# Altium schematic sheet USB & Battery Charging.SchDoc
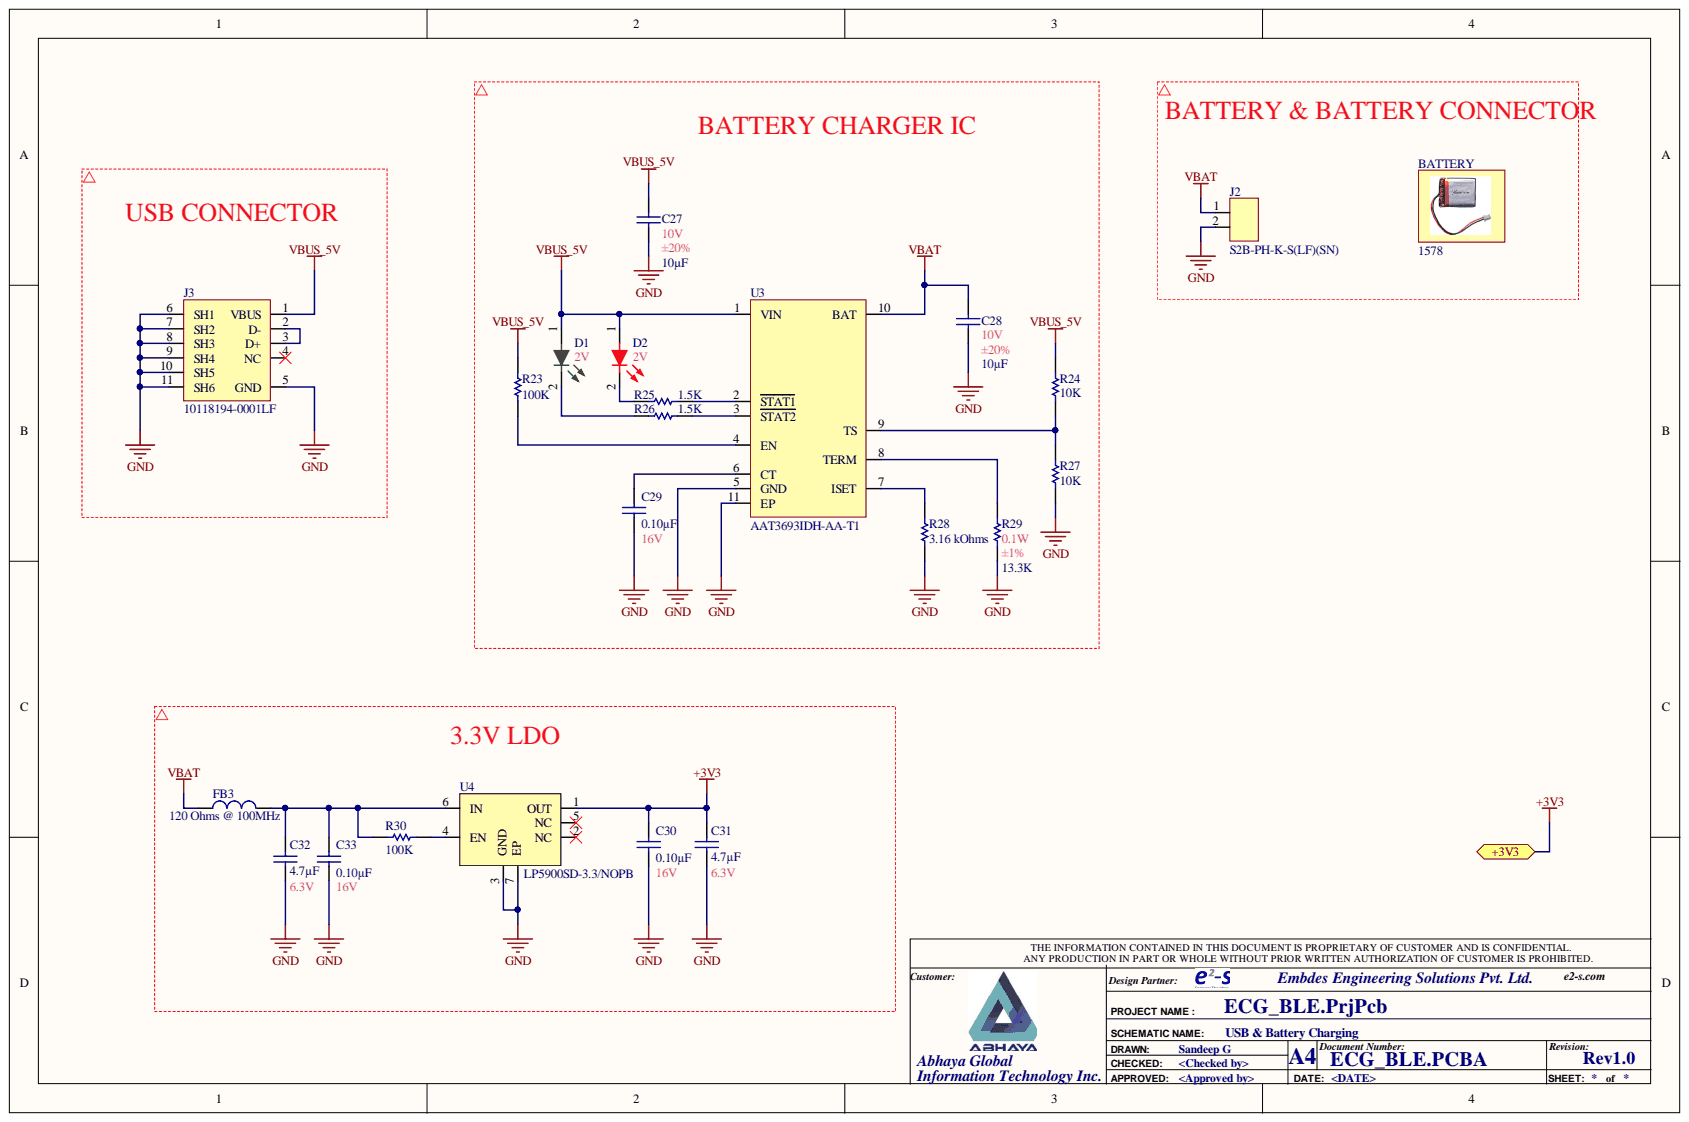
[diagram p0: USB & Battery Charging.SchDoc]

## Parts
BATTERY  value 1578  Manufacturer Part Number: 1578  (hidden comment: BATTERY LITHIUM 3.7V 500MAH)  (net tie)
C27  value 10µF  1st Auxiliary Field: 10V  2nd Auxiliary Field: ±20%  [SMDC0402]  (hidden comment: CAP CER 10UF 10V X5R 0402)
C28  value 10µF  1st Auxiliary Field: 10V  2nd Auxiliary Field: ±20%  [SMDC0402]  (hidden comment: CAP CER 10UF 10V X5R 0402)
C29  value 0.10µF  1st Auxiliary Field: 16V  [SMDC0402]  (hidden comment: CAP CER 0.1UF 16V X7R 0402)
C30  value 0.10µF  1st Auxiliary Field: 16V  [SMDC0402]  (hidden comment: CAP CER 0.1UF 16V X7R 0402)
C31  value 4.7µF  1st Auxiliary Field: 6.3V  [SMDC0402]  (hidden comment: CAP CER 4.7UF 6.3V X5R 0402)
C32  value 4.7µF  1st Auxiliary Field: 6.3V  [SMDC0402]  (hidden comment: CAP CER 4.7UF 6.3V X5R 0402)
C33  value 0.10µF  1st Auxiliary Field: 16V  [SMDC0402]  (hidden comment: CAP CER 0.1UF 16V X7R 0402)
D1  1st Auxiliary Field: 2V  [SMDLED0603]  (hidden comment: LED GREEN CLEAR SMD)
D2  1st Auxiliary Field: 2V  [SMDLEDR0603]  (hidden comment: LED RED CLEAR 0603 SMD)
FB3  value 120 Ohms @ 100MHz  [SMDL0402]  (hidden comment: FERRITE BEAD 120 OHM 0402 1LN)
J2  value S2B-PH-K-S(LF)(SN)  Manufacturer Part Number: S2B-PH-K-S(LF)(SN)  [SHDRRA2W70P0_200_1X2_590X790X550P]  (hidden comment: CONN HOUSING PH 2POS 2MM WHITE)
J3  value 10118194-0001LF  Manufacturer Part Number: 10118194-0001LF  [USB_MICRO_B_10118194-0001LF]  (hidden comment: CONN USB MICRO B RECPT SMT R/A)
R23  value 100K  [SMDRC0402]  (hidden comment: RES SMD 100K OHM 1% 1/10W 0402)
R24  value 10K  [SMDR0402]  (hidden comment: RES SMD 10K OHM 1% 1/10W 0402)
R25  value 1.5K  [SMDR0402]  (hidden comment: RES SMD 1.5K OHM 1% 1/10W 0402)
R26  value 1.5K  [SMDR0402]  (hidden comment: RES SMD 1.5K OHM 1% 1/10W 0402)
R27  value 10K  [SMDR0402]  (hidden comment: RES SMD 10K OHM 1% 1/10W 0402)
R28  value 3.16 kOhms  [SMDR0402]  (hidden comment: RES 3.16K OHM 1% 1/10W 0402)
R29  value 13.3K  1st Auxiliary Field: 0.1W  2nd Auxiliary Field: ±1%  [SMDR0402]  (hidden comment: RES SMD 13.3K OHM 1% 1/10W 0402)
R30  value 100K  [SMDRC0402]  (hidden comment: RES SMD 100K OHM 1% 1/10W 0402)
U3  value AAT3693IDH-AA-T1  Manufacturer Part Number: AAT3693IDH-AA-T1  [SON40P220X220X80-11N]  (hidden comment: 1.6A LINEAR LI-ION CHGR 10TDFN)
U4  value LP5900SD-3.3/NOPB  Manufacturer Part Number: LP5900SD-3.3/NOPB  [SON65P250X80_HS-7N]  (hidden comment: IC REG LINEAR 3.3V 150MA 6WSON)

## Ports
+3V3

## Nets
GND: C27.2 C28.2 C29.1 C30.1 C31.1 C32.1 C33.1 J2.2 J3.5(GND) J3.6(SH1) J3.7(SH2) J3.8(SH3) J3.9(SH4) J3.10(SH5) J3.11(SH6) R27.2 R28.2 R29.2 U3.5(GND) U3.11(EP) U4.3(GND) U4.7(EP)
VBAT: C28.1 FB3.1 J2.1 U3.10(BAT)
VBUS_5V: C27.1 D1.1 D2.1 J3.1(VBUS) R23.1 R24.1 U3.1(VIN)
+3V3: C30.2 C31.2 U4.1(OUT) ; port +3V3
NetC29_2: C29.2 U3.6(CT)
NetC32_2: C32.2 C33.2 FB3.2 R30.1 U4.6(IN)
NetD1_2: D1.2 R26.2
NetD2_2: D2.2 R25.2
NetJ3_2: J3.2(D-) J3.3(D+)
NetR23_2: R23.2 U3.4(EN)
NetR24_2: R24.2 R27.1 U3.9(TS)
NetR25_1: R25.1 U3.2(STAT1)
NetR26_1: R26.1 U3.3(STAT2)
NetR28_1: R28.1 U3.7(ISET)
NetR29_1: R29.1 U3.8(TERM)
NetR30_2: R30.2 U4.4(EN)

Not connected: J3 4(NC); U4 2(NC) 5(NC)

## Library data of the parts
BATTERY = EMS-1578: Manufacturer: Adafruit Industries LLC; Vendor Part Number: 1528-1841-ND; 3rd Auxiliary Field: RoHS Compliant; 4th Auxiliary Field: 503035; Component Height (mm): 4.8mm; Vendor Name: Digi-Key; 1st Auxiliary Field: 3.7V; 2nd Auxiliary Field: 500mAh; Description: BATTERY LITHIUM 3.7V 500MAH; Internal Part Number: EMS-1578; Part Type: BATTERY LITHIUM 3.7V 500MAH; Library Name: ECG_BLE.SchLib
C27 C28 = ECA10U0M10V0-SB: Manufacturer: Samsung Electro-Mechanics America, Inc.; Manufacturer Part Number: CL05A106MP5NUNC; Vendor Part Number: 1276-1450-1-ND; Component Height (mm): 0.70mm; Vendor Name: DigiKey; Component Kind: Standard; Description: CAP CER 10UF 10V X5R 0402; Internal Part Number: ECA10U0M10V0-SB; Library Name: Master_ Library.SchLib; Part Type: CAP CER 10UF 10V X5R 0402; Temp Co: X5R
C29 C30 C33 = ECA0U10K16V0-1-SB: Manufacturer: Samsung Electro-Mechanics America, Inc.; Manufacturer Part Number: CL05B104KO5NNNC; Vendor Part Number: 1276-1001-1-ND; 2nd Auxiliary Field: ±10%; Vendor Name: Digi-Key; Component Kind: Standard; Description: CAP CER 0.1UF 16V X7R 0402; Internal Part Number: ECA0U10K16V0-1-SB; Library Name: Master_ Library.SchLib; Part Type: CAP CER 0.1UF 16V X7R 0402; Temp Co: X7R
C31 C32 = ECA4U70N6V30-SB: Manufacturer: Murata Electronics North America; Manufacturer Part Number: GRM155R60J475ME47D; Vendor Part Number: 490-5915-1-ND; 2nd Auxiliary Field: ±20%; 3rd Auxiliary Field: RoHS Compliant; Component Height (mm): 0.60mm; Temp Co: -55°C ~ 85°C; Vendor Name: Digi-Key; Component Kind: Standard; Description: CAP CER 4.7UF 6.3V X5R 0402; Internal Part Number: ECA4U70N6V30-SB; Library Name: Integrated_Master_Library.IntLib; Part Type: CAP CER 4.7UF 6.3V X5R 0402
D1 = ELDG2V0020M0-SC: Manufacturer: Lite-On Inc.; Manufacturer Part Number: LTST-C191KGKT; Vendor Part Number: 160-1446-1-ND; 2nd Auxiliary Field: 20mA; 3rd Auxiliary Field: RoHS Compliant; Component Height (mm): 0.55mm; Value: LTST-C191KGKT; Vendor Name: Digi-Key; Component Kind: Standard; Description: LED GREEN CLEAR SMD; Internal Part Number: ELDG2V0020M0-SC; Library Name: Master_ Library.SchLib; Part Type: LED GREEN CLEAR SMD
D2 = ELDR2V0020M0-SC-2: Manufacturer: Würth Elektronik; Manufacturer Part Number: 150060RS75000; Vendor Part Number: 732-4978-1-ND; 2nd Auxiliary Field: 20mA; 3rd Auxiliary Field: RoHS Compliant; Component Height (mm): 0.8mm; Value: 150060RS75000; Vendor Name: Digi-Key; Component Kind: Standard; Description: LED RED CLEAR 0603 SMD; Internal Part Number: ELDR2V0020M0-SC-2; Library Name: Master_ Library.SchLib; Part Type: LED RED CLEAR 0603 SMD
FB3 = EMS-BLM15PX121SN1D: Manufacturer: Murata Electronics; Vendor Part Number: 490-9656-1-ND; 1st Auxiliary Field: 2A; 2nd Auxiliary Field: 55mOhm; 3rd Auxiliary Field: ROHS3 Compliant; Component Height (mm): 0.55mm; Temp Co: -55°C ~ 125°C; Vendor Name: Digi-Key; Component Kind: Standard; Description: FERRITE BEAD 120 OHM 0402 1LN; Internal Part Number: EMS-BLM15PX121SN1D; Manufacturer Part Number: BLM15PX121SN1D; Part Type: FERRITE BEAD 120 OHM 0402 1LN; Library Name: ECG_BLE.SchLib
J2 = ECNJSTHE02-T: Manufacturer: JST Sales America Inc.; Vendor Part Number: 455-1719-ND; Component Height (mm): 4.8mm; Vendor Name: Digi-Key; 1st Auxiliary Field: 2 Pos; 2nd Auxiliary Field: 2mm; Component Kind: Standard; Description: CONN HOUSING PH 2POS 2MM WHITE; Internal Part Number: ECNJSTHE02-T; Library Name: Master_ Library.SchLib; Part Type: CONN HOUSING PH 2POS 2MM WHITE
J3 = EINUSBBR06-S-1364: Manufacturer: Amphenol FCI; Vendor Part Number: 609-4618-1-ND; 1st Auxiliary Field: USB - micro B; 2nd Auxiliary Field: 5 POS; 3rd Auxiliary Field: RoHS Compliant; Component Height (mm): 3mm; Temp Co: -30°C ~ 80°C; Vendor Name: Digi-Key; Component Kind: Standard; Description: CONN USB MICRO B RECPT SMT R/A; Internal Part Number: EINUSBBR06-S-1364; Library Name: Master_ Library.SchLib; Part Type: CONN USB MICRO B RECPT SMT R/A
R23 R30 = ERE100KG110-SB: Manufacturer: Panasonic Electronic Components; Manufacturer Part Number: ERJ-2RKF1003X; Vendor Part Number: P100KLCT-ND; 1st Auxiliary Field: 0.1W; Component Height (mm): 0.40mm; Temp Co: ±100ppm/°C; Vendor Name: Digi-Key; 2nd Auxiliary Field: ±1%; Component Kind: Standard; Description: RES SMD 100K OHM 1% 1/10W 0402; Internal Part Number: ERE100KG110-SB; Library Name: Master_ Library.SchLib; Part Type: RES SMD 100K OHM 1% 1/10W 0402
R24 R27 = ERE10K0G110-SB: Manufacturer: Panasonic Electronic Components; Manufacturer Part Number: ERJ-2RKF1002X; Vendor Part Number: P10.0KLCT-ND; 1st Auxiliary Field: 0.1W; Component Height (mm): 0.40mm; Temp Co: ±100ppm/°C; Vendor Name: Digi-Key; 2nd Auxiliary Field: ±1%; Component Kind: Standard; Description: RES SMD 10K OHM 1% 1/10W 0402; Internal Part Number: ERE10K0G110-SB; Library Name: Master_ Library.SchLib; Part Type: RES SMD 10K OHM 1% 1/10W 0402
R25 R26 = ERE1K50G110-SB: Manufacturer: Panasonic Electronic Components; Manufacturer Part Number: ERJ-2RKF1501X; Vendor Part Number: P1.50KLCT-ND; 1st Auxiliary Field: 0.1W; Component Height (mm): 0.40mm; Temp Co: ±100ppm/°C; Vendor Name: Digi-Key; 2nd Auxiliary Field: ±1%; Component Kind: Standard; Description: RES SMD 1.5K OHM 1% 1/10W 0402; Internal Part Number: ERE1K50G110-SB; Library Name: Master_ Library.SchLib; Part Type: RES SMD 1.5K OHM 1% 1/10W 0402
R28 = ERE3K16G110-SB: Manufacturer: KOA Speer Electronics, Inc.; Manufacturer Part Number: RK73H1ETTP3161F; Vendor Part Number: 2019-RK73H1ETTP3161FCT-ND; 1st Auxiliary Field: 0.1W, 1/10W; 3rd Auxiliary Field: ROHS3 Compliant; Component Height (mm): 0.40mm; Temp Co: -55°C ~ 155°C; Vendor Name: Digi-Key; 2nd Auxiliary Field: ±1%; Component Kind: Standard; Description: RES 3.16K OHM 1% 1/10W 0402; Internal Part Number: ERE3K16G110-SB; Part Type: RES 3.16K OHM 1% 1/10W 0402; Library Name: ECG_BLE.SchLib
R29 = ERE13K3G110-SB: Manufacturer: Panasonic Electronic Components; Manufacturer Part Number: ERJ-2RKF1332X; Vendor Part Number: P13.3KLCT-ND; Component Height (mm): 0.40mm; Temp Co: ±100ppm/°C; Vendor Name: Digi-Key; Component Kind: Standard; Description: RES SMD 13.3K OHM 1% 1/10W 0402; Internal Part Number: ERE13K3G110-SB; Library Name: Master_ Library.SchLib; Part Type: RES SMD 13.3K OHM 1% 1/10W 0402
U3 = EICSSTDFN-10-****: Manufacturer: Skyworks Solutions Inc.; Vendor Part Number: 863-1512-1-ND; 1st Auxiliary Field: 7.5V; 3rd Auxiliary Field: RoHS Compliant; Component Height (mm): 0.8mm; Temp Co: -40°C ~ 85°C (TA); Vendor Name: Digi-Key; 2nd Auxiliary Field: 1.6A; Component Kind: Standard; Description: 1.6A LINEAR LI-ION CHGR 10TDFN; Internal Part Number: EICSSTDFN-10-****; Part Type: 1.6A LINEAR LI-ION CHGR 10TDFN; Library Name: ECG_BLE.SchLib
U4 = EICTIWSON-6-1700: Manufacturer: Texas Instruments; Vendor Part Number: LP5900SD-3.3/NOPBCT-ND; 1st Auxiliary Field: 3.3V ~ 5.5V; 3rd Auxiliary Field: RoHS Compliant; Component Height (mm): 0.8mm; Temp Co: -40°C ~ 85°C (TA); Vendor Name: Digi-Key; 2nd Auxiliary Field: 150mA; Component Kind: Standard; Description: IC REG LINEAR 3.3V 150MA 6WSON; Internal Part Number: EICTIWSON-6-1700; Library Name: Integrated_Master_Library.IntLib; Part Type: IC REG LINEAR 3.3V 150MA 6WSON
